# Altium schematic sheet Sheet2.SchDoc(Sheet2)
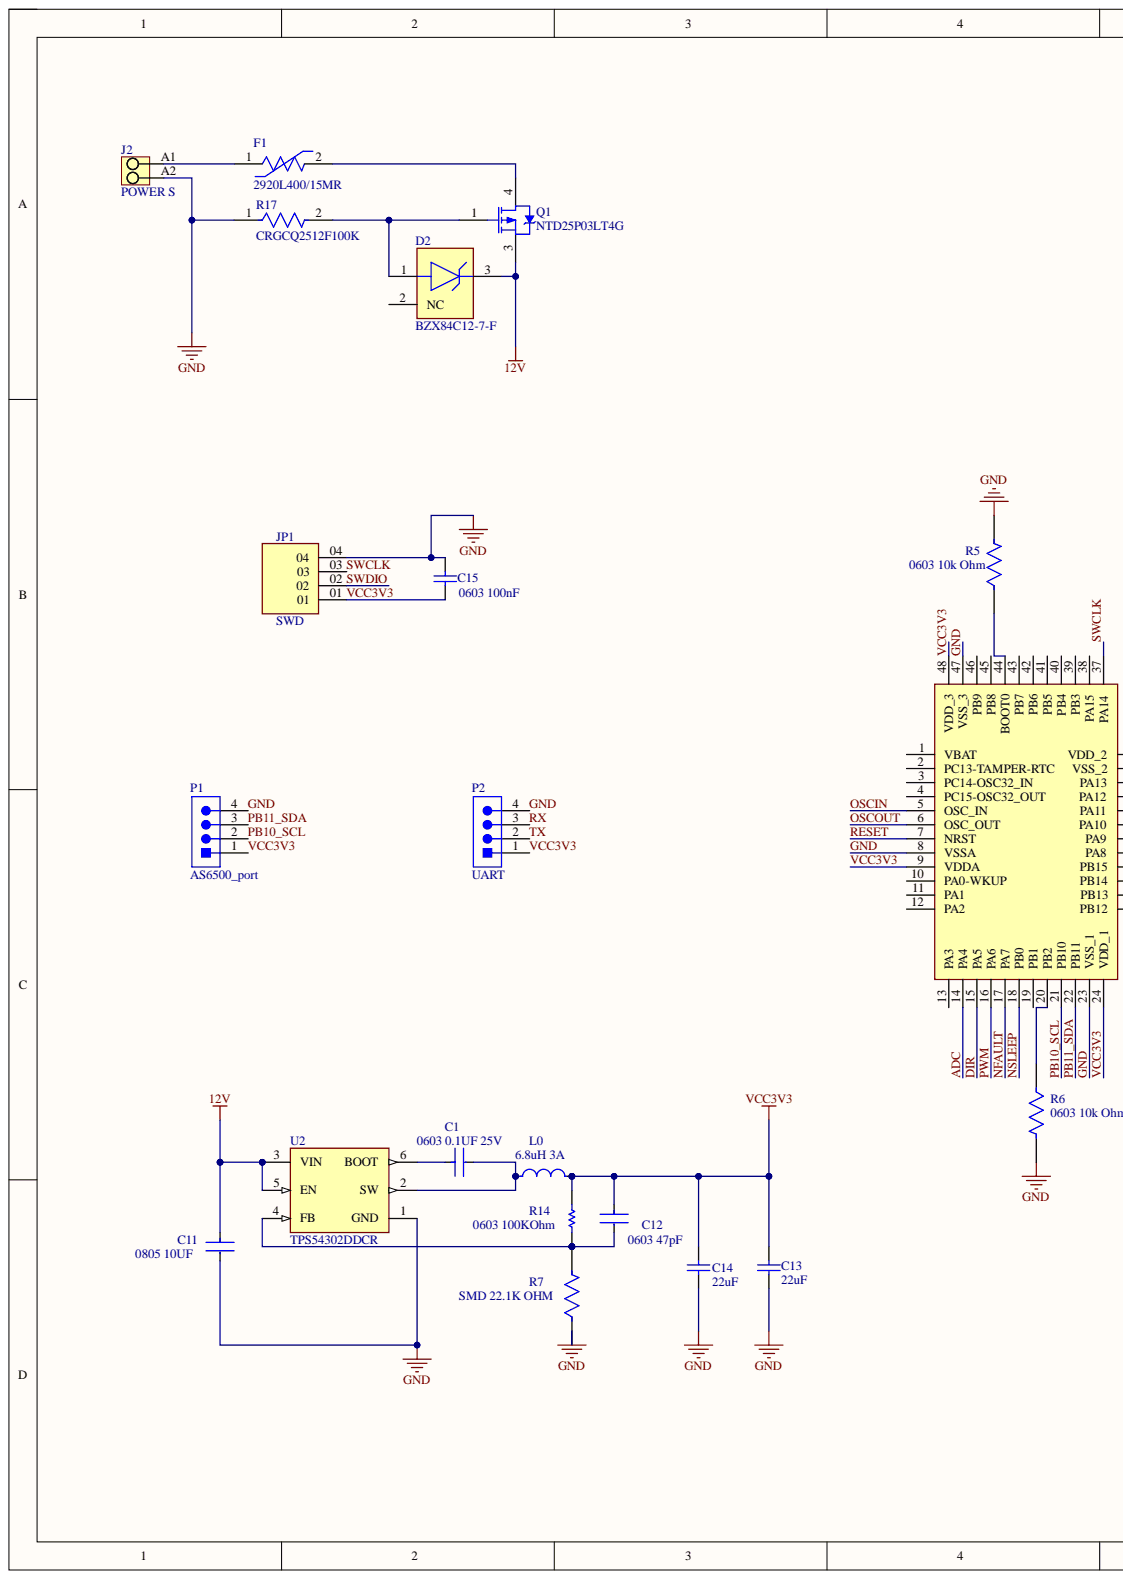
[diagram p0: Sheet2.SchDoc, left]
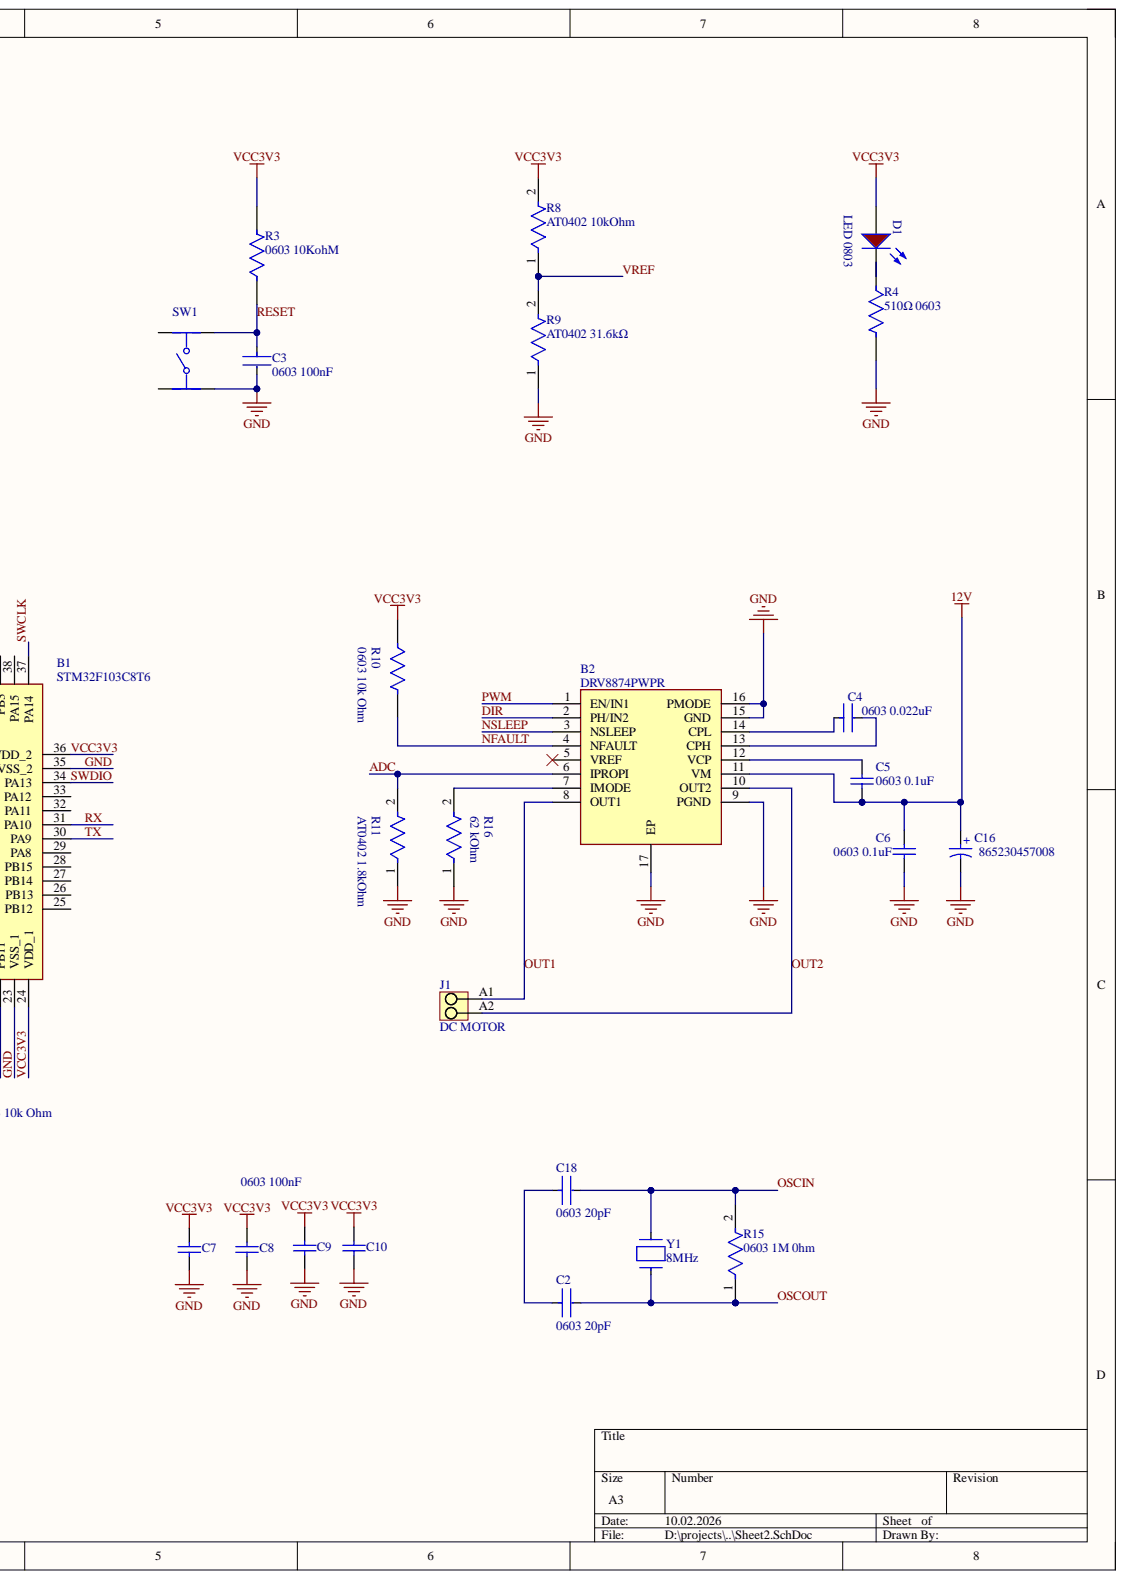
[diagram p1: Sheet2.SchDoc, right]

## Parts
B1  STM32F103C8T6  [QFP50P900X900X160-48N]
B2  DRV8874PWPR  [PWP0016J_L]
C1  0603 0.1UF 25V  [FP-C0603C-CF-MFG]
C2  0603 20pF  value 20 pF  [FP-C0603C-CF-MFG]
C3  0603 100nF  value 100 nF  [FP-CC0603-DA-MFG]
C4  0603 0.022uF  [FP-C0603C-CF-MFG]
C5  0603 0.1uF  [CAPC1608X90X35NL15T15]
C6  0603 0.1uF  [CAPC1608X90X35NL15T15]
C7  0603 100nF  [CAPC1608X92X38NL15T08]
C8  0603 100nF  [CAPC1608X92X38NL15T08]
C9  0603 100nF  [CAPC1608X92X38NL15T08]
C10  0603 100nF  [CAPC1608X92X38NL15T08]
C11  0805 10UF  [FP-C0805C106K3PACTU-MFG]
C12  0603 47pF  value 47 pF  [FP-C0603C-CF-MFG]
C13  22uF  [CAPC2013X152X50ML20T25]
C14  22uF  [CAPC2013X152X50ML20T25]
C15  0603 100nF  [CAPC1608X92X38NL15T08]
C16  865230457008  [WCAP-AS5H_D10H10.5]
C18  0603 20pF  value 20 pF  [FP-C0603C-CF-MFG]
D1  LED 0803  [WL-SMCW_0805_150080xx75000]
D2  BZX84C12-7-F  [FP-SOT23-MFG]
F1  2920L400/15MR  [FP-2920L400-15MR-MFG]
J1  DC MOTOR  [LM_5_08_90_02]
J2  POWER S  [LM_5_08_90_02]
JP1  SWD  [SAMTEC_IPL1-104-01-X-S-K]
L0  6.8uH 3A  [FP-NR6045-MFG]
P1  AS6500_port  [JST-B4B-PH-K-S_V]
P2  UART  [JST-B4B-PH-K-S_V]
Q1  NTD25P03LT4G  [ONSC-DPAK-3-369C-01_V]
R3  0603 10KohM  [FP-CRGCQ0603-IPC_C]
R4  510Ω 0603  [FP-AC0603-MFG]
R5  0603 10k Ohm  [FP-AC0603-IPC_A]
R6  0603 10k Ohm  Case/Package: 0603  [FP-AC0603-IPC_A]
R7  SMD 22.1K OHM  [FP-RT0805-MFG]
R8  AT0402 10kOhm  [FP-AT0402-IPC_A]
R9  AT0402 31.6kΩ  [FP-AT0402-IPC_A]
R10  0603 10k Ohm  Case/Package: 0603  [FP-AC0603-IPC_A]
R11  AT0402 1.8kOhm  [FP-AT0402-IPC_B]
R14  0603 100KOhm  [RESC1608X55X25ML10T15]
R15  0603 1M 0hm  [FP-MCT0603-IPC_A]
R16  62 kOhm  [FP-ESR18-IPC_C]
R17  CRGCQ2512F100K  [FP-CRGCQ2512-IPC_C]
SW1  PTS645SM43SMTR92LFS  [PTS645SM43SMTR92LFS_MFG]  (value not drawn)
U2  TPS54302DDCR  [FP-DDC0006A-MFG]
Y1  8MHz  [FP-ABM3-MFG]

## Nets
12V: B2.11(VM) C5.1 C6.1 C11.1 C16.1 D2.3 Q1.3 U2.3(VIN) U2.5(EN)
ADC: B1.14(PA4) B2.6(IPROPI) R11.2
DIR: B1.15(PA5) B2.2(PH/IN2)
GND: B1.8(VSSA) B1.23(VSS_1) B1.35(VSS_2) B1.47(VSS_3) B2.9(PGND) B2.15(GND) B2.16(PMODE) B2.17(EP) C3.2 C6.2 C7.1 C8.1 C9.1 C10.1 C11.2 C13.1 C14.1 C15.2 C16.2 J2.A2 JP1.04 P1.4 P2.4 R4.2 R5.2 R6.2 R7.1 R9.1 R11.1 R16.1 R17.1 SW1.4 U2.1(GND)
NFAULT: B1.17(PA7) B2.4(NFAULT) R10.2
NSLEEP: B1.18(PB0) B2.3(NSLEEP)
OSCIN: B1.5(OSC_IN) C18.2 R15.2 Y1.2
OSCOUT: B1.6(OSC_OUT) C2.2 R15.1 Y1.1
OUT1: B2.8(OUT1) J1.A1
OUT2: B2.10(OUT2) J1.A2
PB10_SCL: B1.21(PB10) P1.2
PB11_SDA: B1.22(PB11) P1.3
PWM: B1.16(PA6) B2.1(EN/IN1)
RESET: B1.7(NRST) C3.1 R3.1 SW1.2
RX: B1.31(PA10) P2.3
SWCLK: B1.37(PA14) JP1.03
SWDIO: B1.34(PA13) JP1.02
TX: B1.30(PA9) P2.2
VCC3V3: B1.9(VDDA) B1.24(VDD_1) B1.36(VDD_2) B1.48(VDD_3) C7.2 C8.2 C9.2 C10.2 C12.1 C13.2 C14.2 C15.1 D1.2 JP1.01 L0.2 P1.1 P2.1 R3.2 R8.2 R10.1 R14.2
VREF: R8.1 R9.2
NetB1_20: B1.20(PB2) R6.1
NetB1_44: B1.44(BOOT0) R5.1
NetB2_7: B2.7(IMODE) R16.2
NetB2_12: B2.12(VCP) C5.2
NetB2_13: B2.13(CPH) C4.2
NetB2_14: B2.14(CPL) C4.1
NetC1_1: C1.1 U2.6(BOOT)
NetC1_2: C1.2 L0.1 U2.2(SW)
NetC2_1: C2.1 C18.1
NetC12_2: C12.2 R7.2 R14.1 U2.4(FB)
NetD1_1: D1.1 R4.1
NetD2_1: D2.1 Q1.1 R17.2
NetF1_1: F1.1 J2.A1
NetF1_2: F1.2 Q1.4

Not connected: B1 1(VBAT) 2(PC13-TAMPER-RTC) 3(PC14-OSC32_IN) 4(PC15-OSC32_OUT) 10(PA0-WKUP) 11(PA1) 12(PA2) 13(PA3) 19(PB1) 25(PB12) 26(PB13) 27(PB14) 28(PB15) 29(PA8) 32(PA11) 33(PA12) 38(PA15) 39(PB3) 40(PB4) 41(PB5) 42(PB6) 43(PB7) 45(PB8) 46(PB9); B2 5(VREF); D2 2; SW1 1 3

## Library data of the parts
B1 = STM32F103CBT6: Medium-density performance line Arm-based 32-bit MCU with 64 or 128 KB Flash, USB, CAN, 7 timers, 2 ADCs, 9 com. interfaces; Manufacturer_Name: STMicroelectronics; Geometry.Height: 1.6mm; Arrow Part Number: STM32F103CBT6; Arrow Price/Stock: https://www.arrow.com/en/products/stm32f103cbt6/stmicroelectronics.html?utm_currency=USD&region=asia; Component Kind: Standard; Datasheet Link: https://www.st.com/resource/en/datasheet/stm32f103c8.pdf; Library Name: STM32F103CBT6.SchLib; Manufacturer_Part_Number: STM32F103CBT6; Mouser Part Number: 511-STM32F103CBT6; Mouser Price/Stock: https://www.mouser.co.uk/ProductDetail/STMicroelectronics/STM32F103CBT6?qs=WxFF5lh7QM3goh6GV5Ogig%3D%3D
B2 = DRV8874PWPR: H-Bridge Motor Driver With Integrated Current Sense and Regulation; Manufacturer_Name: Texas Instruments; Geometry.Height: 1.2mm; Arrow Part Number: DRV8874PWPR; Arrow Price/Stock: https://www.arrow.com/en/products/drv8874pwpr/texas-instruments.html?utm_currency=USD&region=nac; Component Kind: Standard; Datasheet Link: https://www.ti.com/lit/gpn/DRV8874; Library Name: DRV8874PWPR.SchLib; Manufacturer_Part_Number: DRV8874PWPR; Mouser Part Number: 595-DRV8874PWPR; Mouser Price/Stock: https://www.mouser.co.uk/ProductDetail/Texas-Instruments/DRV8874PWPR?qs=mAH9sUMRCtvh%2FSU15ywmDA%3D%3D
C1 = CMP-2006-03731-2: CAP CER 0.1UF 25V X7R 0603; Manufacturer: KEMET; Manufacturer Part Number: C0603C104K3RACAUTO; Automotive Grade: Grade 1; Capacitance: 0.10 μF; Material: Ceramic; Temperature Coefficient: 30ppm/°C; Tolerance: 0.1; Value: 0.10 μF; Automotive: Yes; Category: Cap; Component Kind: Standard; ComponentLink2Description: Datasheet; ComponentLink2URL: https://api.kemet.com/component-edge/download/datasheet/C0603C100J5GACAUTO.pdf; Device Class L1: Passive Components; Device Class L2: Capacitors; Device Class L3: Ceramic Capacitors; Digikey Description: CAP CER 0.1UF 25V X7R 0603; Height: 0.87mm; Lead Free: Yes; Library Name: Altium Content Vault; Mouser Description: Multilayer Ceramic Capacitors MLCC - SMD/SMT 0.1uF 25volts 10% X7R AUTO; Octopart: https://octopart.com/c0603c104k3racauto-kemet-12595587; Package: C0603C-CF; RoHS: TRUE; Temperature: -55°C to +125°C; Temperature Characteristic: X7R; Temperature Range High: +125°C; Temperature Range Low: -55°C; Voltage Rating: 25 V
C2 C18 = CMP-03020-010331-1: C0603 20 pF X8R 30ppm/°C 1.00% 25 V; Manufacturer: KEMET; Manufacturer Part Number: C0603C200F3HACTU; Automotive Grade: Grade 1; Material: Ceramic; Tolerance: 0.01; Voltage Rating: 25 V; Automotive: Yes; Capacitance: 20 pF; Category: Cap; Component Kind: Standard; ComponentLink2Description: Datasheet; ComponentLink2URL: https://api.kemet.com/component-edge/download/datasheet/C0603C100J5GACAUTO.pdf; Device Class L1: Passive Components; Device Class L2: Capacitors; Device Class L3: Ceramic Capacitors; Height: 0.87mm; Lead Free: Yes; Library Name: Altium Content Vault; Octopart: https://octopart.com/c0603c200f3hactu-kemet-88725274; Package: C0603C-CF; RoHS: TRUE; Temperature: -55°C to +125°C; Temperature Characteristic: X8R; Temperature Coefficient: 30ppm/°C; Temperature Range High: +125°C; Temperature Range Low: -55°C; Value: 20 pF
C3 = CMP-03422-001228-1: CAP CER 0.1UF 10V X7R 0603; Manufacturer: YAGEO; Manufacturer Part Number: CC0603KRX7R6BB104; Material: Ceramic; Temperature Coefficient: 15%; Tolerance: 0.1; Automotive: No; Capacitance: 100 nF; Category: Cap; Component Kind: Standard; ComponentLink2Description: Datasheet; ComponentLink2URL: http://www.yageo.com/documents/recent/UPY-GPHC_X7R_6.3V-to-50V_18.pdf; Device Class L1: Passive Components; Device Class L2: Capacitors; Device Class L3: Ceramic Capacitors; Digikey Description: CAP CER 0.1UF 10V X7R 0603; Footprint Url: http://www.yageo.com/exep/pages/download/literatures/UPY-C_GEN_24.pdf; Height: 0.9mm; Lead Free: Yes; Library Name: Altium Content Vault; Mouser Description: 0603 0.1 uF 10 V ±10 % Tolerance X7R SMT Multilayer Ceramic Capacitor; Octopart: https://octopart.com/cc0603krx7r6bb104-yageo-9291607; Package: CC0603-DA; RoHS: TRUE; Temperature: -55°C to +125°C; Temperature Characteristic: X7R; Temperature Range High: +125°C; Temperature Range Low: -55°C; Value: 100 nF; Voltage: 10 V; Voltage Rating: 10 V
C4 = CMP-03020-003229-1: CAP CER 0.022UF 50V X7R 0603; Manufacturer: KEMET; Manufacturer Part Number: C0603C223M5RAC7867; Automotive Grade: Grade 1; Capacitance: 22,000 pF; Material: Ceramic; Temperature Coefficient: 30ppm/°C; Tolerance: 0.2; Value: 22,000 pF; Automotive: Yes; Category: Cap; Component Kind: Standard; ComponentLink2Description: Datasheet; ComponentLink2URL: https://api.kemet.com/component-edge/download/datasheet/C0603C100J5GACAUTO.pdf; Device Class L1: Passive Components; Device Class L2: Capacitors; Device Class L3: Ceramic Capacitors; Digikey Description: CAP CER 0.022UF 50V X7R 0603; Height: 0.87mm; Lead Free: Yes; Library Name: Altium Content Vault; Octopart: https://octopart.com/c0603c223m5rac7867-kemet-1577116; Package: C0603C-CF; RoHS: TRUE; Temperature: -55°C to +125°C; Temperature Characteristic: X7R; Temperature Range High: +125°C; Temperature Range Low: -55°C; Voltage Rating: 50 V
C5 C6 = CMP-2006-02299-1: Manufacturer: Kemet; Part Number: C0603C104K4RAC7013; Component Kind: Standard; Library Name: Altium Content Vault
C7-C10 C15 = CMP-2006-01211-1: Manufacturer: Vitramon; Part Number: VJ0603Y104KXJAP; Component Kind: Standard; Library Name: Altium Content Vault
C11 = CMP-03020-034663-1: CAP CER 10UF 25V X5R 0805; Dielectric Material: Ceramic; Dissipation Factor: 10%; Capacitance: 10uF; Case Code (Imperial): 0805; Case Code (Metric): 2012; Case/Package: 0805; Component Kind: Standard; Depth: 1.25mm; Dielectric: X5R; Height: 1.25mm; Lead Free: Lead Free; Length: 0.079inch; Library Name: Altium Content Vault; Max Operating Temperature: 85°C; Min Operating Temperature: -55°C; Mount: Surface Mount; Packaging: Cut Tape; REACH SVHC: No SVHC; RoHS Compliant: Yes; Termination: Solder; Thickness: 0.057inch; Tolerance: 10%; Voltage: 25V; Voltage Rating: 25V; Voltage Rating (DC): 25V; Width: 0.049inch
C12 = CMP-03020-013808-1: C0603 47 pF X7R 30ppm/°C 10.00% 100 V; Manufacturer: KEMET; Manufacturer Part Number: C0603C470K1RACTU; Automotive Grade: Grade 1; Material: Ceramic; Tolerance: 0.1; Voltage Rating: 100 V; Automotive: Yes; Capacitance: 47 pF; Category: Cap; Component Kind: Standard; ComponentLink2Description: Datasheet; ComponentLink2URL: https://api.kemet.com/component-edge/download/datasheet/C0603C100J5GACAUTO.pdf; Device Class L1: Passive Components; Device Class L2: Capacitors; Device Class L3: Ceramic Capacitors; Height: 0.87mm; Lead Free: Yes; Library Name: Altium Content Vault; Mouser Description: Cap Ceramic 47pF 100V X7R 10% SMD 0603 125°C Punched Paper T/R; Octopart: https://octopart.com/c0603c470k1ractu-kemet-51453601; Package: C0603C-CF; RoHS: TRUE; Temperature: -55°C to +125°C; Temperature Characteristic: X7R; Temperature Coefficient: 30ppm/°C; Temperature Range High: +125°C; Temperature Range Low: -55°C; Value: 47 pF
C13 C14 = CMP-2007-04522-1: Manufacturer: AVX Interconnect / Elco; Part Number: 0805ZD226MAT2A; Component Kind: Standard; Library Name: Altium Content Vault
C16 = CMP-03913-53914687-2: WCAP-AS5H Aluminum Electrolytic Capacitors; Capacitance: 330µF; Insulation Resistance: 303.03kΩ; Leakage Current: 82.5µA; Life (Hours): 5000hours; Polarity: Polar; Ripple Current: 375mA; Tolerance: 20%; Voltage Rating: 25V; Case/Package: Radial; Component Kind: Standard; Diameter: 10mm; Height: 10.5mm; Height - Seated (Max): 10.4902mm; Length: 10.5mm; Library Name: Altium Content Vault; Max Operating Temperature: 105°C; Min Operating Temperature: -40°C; Mount: Surface Mount; REACH SVHC: Unknown; Ripple Current (AC): 375mA; RoHS: Compliant; Voltage Rating (DC): 25V; Width: 10.3mm
D1 = CMP-1426-00010-5: WL-SMCW SMD chip LED top view monocolor waterclear; Manufacturer Lifecycle Status: ACTIVE; Manufacturer Package Identifier: 0805 (2012 metric); Color: Red; Dominant Wavelength: 630nm; Forward Current: 100mA; Forward Voltage: 1.9V; Lens Style: Clear, Rectangular; Luminous Intensity: 60mcd; Max Junction Temperature (Tj): 95°C; Max Reverse Voltage (DC): 5V; Peak Wavelength: 645nm; Power Dissipation: 72mW; Test Current: 20mA; Viewing Angle: 140°; YEOL: 1 = Estimated lifetime > 10 years; Case Code (Imperial): 0805; Case Code (Metric): 2012; Case/Package: 0805; Component Kind: Standard; Height: 700µm; Length: 2mm; Library Name: Altium Content Vault; Lifecycle Status: Production; Max Operating Temperature: 85°C; Min Operating Temperature: -40°C; Mount: Surface Mount; Packaging: Tape and Reel; REACH SVHC: Unknown; Reverse Voltage: 5V; RoHS: Compliant; Wavelength: 630nm; Width: 1.25mm
D2 = CMP-12792-000017-1: DIODE ZENER 12V 300MW SOT23-3; Manufacturer: Diodes Inc.; Max Junction Temp: +150°C; Max Reverse Leakage Current: 0.1uA; Max Zener Voltage: 12.7V; Reverse Voltage: 8V; Standoff Height: 0.013mm; Zener Impedance: 25Ω; Automotive: No; Category: Diode; Component Kind: Standard; ComponentLink2Description: Datasheet; ComponentLink2URL: https://www.diodes.com/assets/Datasheets/ds18001.pdf; Device Class L1: Discrete Semiconductors; Device Class L2: Diodes; Device Class L3: Zener Diodes; Digikey Description: DIODE ZENER 12V 300MW SOT23-3; Footprint Url: https://www.diodes.com/assets/Package-Files/SOT23.pdf; Height: 1.1mm; Ipc Land Pattern Name: SOT92P240X102-3; Lead Free: Yes; Library Name: Altium Content Vault; Manufacturer Part Number: BZX84C12-7-F; Mouser Description: Zener Diodes 12V 350mW; Nom Zener Voltage: 12V; Octopart: https://octopart.com/bzx84c12-7-f-diodes+inc.-327696; Package: SOT23; Power Dissipation: 300mW; RoHS: TRUE; Temperature: -65°C to +150°C; Temperature Range High: +150°C; Temperature Range Low: -65°C
F1 = CMP-08606-000010-2: PTC RESET FUSE 15V 4A 2920; Approvals: TUV; Max Current Rating: 40A; Time to Trip: 4s; Trip Current: 8A; Case Code (Imperial): 2920; Case Code (Metric): 7351; Component Kind: Standard; Hold Current: 4A; Library Name: Altium Content Vault; Max Operating Temperature: 85°C; Min Operating Temperature: -40°C; Mount: Surface Mount; Packaging: Tape and Reel
J1 J2 = CMP-1559-01766-1: OMNIMATE Signal - series LM; Manufacturer: Weidmueller; PartNumber: 1716080000; Contact_material: Cu-Leg; Contact_surface: tinned; Insulating_material: Wemid (PA); Mounting_onto_the_PCB: Solder connection; No_of_poles: 2; Pitch_inch: 0,2 inch; Pitch_mm: 5,08 mm; Rated_current_use_group_B_UL_1059: 15 A; Rated_voltage_for_surge_voltage_class_pollution_degree_II_2: 630 V; Rated_voltage_use_group_B_UL_1059: 300 V; Short_text_for_material: LM 5.08/02/90 3.5SN OR BX; Side_termination_characteristic: closed side; Solder_pin_length_mm: 3,5 mm; Solid_max_H05_07_V_U: 2,5 mm²; Solid_min_H05_07_V_U: 0,2 mm²; UL_94_flammability_rating: V-0; Wire_cross_section_AWG_max_UL_1059: AWG 14; Wire_cross_section_AWG_min_UL_1059: AWG 24; Colour: Orange; Component Kind: Standard; ComponentLink1Description: Manufacturer URL; ComponentLink1URL: http://www.weidmueller.com/; ComponentLink2Description: URL Link1; ComponentLink2URL: http://catalog.weidmueller.com/catalog/Start.do?localeId=en&ObjectID=1716080000; Library Name: Altium Content Vault; PackageReference: LM_5_08_90_02; Packaging: Box; Qty: 500 PCS; Revision: V1
JP1 = IPL1-104-01-X-S-K: MF: Samtec; Availability: Unavailable; Component Kind: Standard; Library Name: IPL1-104-01-X-S-K.IntLib; MP: IPL1-104-01-X-S-K; Package: None; Price: None
L0 = CMP-14484-000868-1: Shielded Wirewound Inductor 6.8uH 20% 3.3A 46.8mΩ SMD; Component Kind: Standard; Library Name: Altium Content Vault; RoHS Compliant: Yes
P1 P2 = CMP-2000-05165-1: Male Header, Pitch 2 mm, 1 x 4 Position, Height 6 mm, Tail Length 3.4 mm, -25 to 85 degC, RoHS, Bulk; Manufacturer: JST; No of Positions: 4; Pitch: 2mm; Style/Orientation: StraightHeader/Plug; Component Kind: Standard; Datasheet URL: http://datasheet.octopart.com/B4B-PH-K-S%28LF%29%28SN%29-JST-datasheet-10558886.pdf; Datasheet Version: Apr-09; Library Name: Altium Content Vault; Manufacturer URL: http://www.jst.com/home2.html; Termination: Crimp; Type: ShroudedHeader
Q1 = CMP-1058-00409-1: Power MOSFET, -25 A, -30 V, Logic Level P-Channel, 3-Pin DPAK, Pb-Free, Tape and Reel; Manufacturer: On Semiconductor; Channel Polarity: P Channel; Ciss Typ (pF): 900; Configuration: Single; Coss Typ (pF): 290; Crss Typ (pF): 105; ID Max (A): 25; PD Max (W): 75; Qrr Typ (nC): 35; V(BR)DSS Min (V): 30; VGS Max (V): 15; VGS(th) Max (V): 2; Component Kind: Standard; ComponentLink1Description: Manufacturer URL; ComponentLink1URL: http://www.onsemi.com/; ComponentLink2Description: Datasheet; ComponentLink2URL: http://www.onsemi.cn/pub_link/Collateral/NTD25P03L-D.PDF; ComponentLink3Description: Package Specification; ComponentLink3URL: http://www.onsemi.cn/pub_link/Collateral/369C.PDF; DatasheetVersion: Rev. 4; Library Name: Altium Content Vault; Mounting Technology: Surface Mount; Package Type: DPAK-3; PackageDescription: 3-Pin Flange Mount, Body 6.54 x 6.095 mm, Pitch 2.29 mm; PackageReference: DPAK-3-369C-01; PackageVersion: Rev. E, 02/2014; Packing: Tape and Reel; PartNumber: NTD25P03LT4G; RoHS: Pb-Free
R3 = CMP-03211-009884-1: CRGCQ 0603 10K 5%; Manufacturer: TE Connectivity; Manufacturer Part Number: CRGCQ0603J10K; Power Rating: 0.1W; Resistance: 10KΩ; Temperature Coefficient: ±100ppm/°C; Tolerance: 0.05; Value: 10KΩ; Voltage Rating: 75V; Ambient Temperature Range High: +70°C; Category: Res; Component Kind: Standard; ComponentLink2Description: Datasheet; ComponentLink2URL: https://www.te.com/commerce/DocumentDelivery/DDEController?Action=showdoc&DocId=Data+Sheet%7F1773204-3%7F1%7Fpdf%7FEnglish%7FENG_DS_1773204-3_1.pdf%7F4-2176339-923; Composition: Thick Film; Device Class L1: Passive Components; Device Class L2: Resistors; Device Class L3: Chip SMD Resistors; Digikey Description: CRGCQ 0603 10K 5%; Height: 0.55mm; Ipc Land Pattern Name: RESC16080X45; Lead Free: Yes; Library Name: Altium Content Vault; Mouser Description: Res Thick Film 0603 10K Ohm 5% 0.1W(1/10W) ±100ppm/C Pad SMD Automotive T/R; Octopart: https://octopart.com/crgcq0603j10k-te+connectivity-91018097; Package: CRGCQ0603; RoHS: TRUE; Series: CRGCQ; Temperature: -55°C to +155°C; Temperature Range High: +155°C; Temperature Range Low: -55°C
R4 = CMP-03412-039135-1: RES 510Ω 5.00% 0.1W 0603; Component Kind: Standard; Library Name: Altium Content Vault
R5 R6 R10 = CMP-03412-042500-1: RES 10kΩ 5.00% 0.1W 0603; Resistance: 10kR; Tolerance: 5%; Component Kind: Standard; Lead Free: Lead Free; Library Name: Altium Content Vault; RoHS Compliant: Yes
  R5: Case/Package: 0603
R7 = CMP-03412-036974-1: RES SMD 22.1K OHM 1% 1/8W 0805; Manufacturer: Yageo; Manufacturer Part Number: RT0805FRD0722K1L; Power Rating: 0.125W; Resistance: 22.1KΩ; Temperature Coefficient: 25 ppm/°C; Tolerance: 0.0001; Value: 22.1KΩ; Voltage Rating: 150V; Automotive: No; Category: Res; Component Kind: Standard; ComponentLink2Description: Datasheet; ComponentLink2URL: http://www.yageo.com/documents/recent/PYu-RT_1-to-0.01_RoHS_L_9.pdf; Composition: Thin Film; Device Class L1: Passive Components; Device Class L2: Resistors; Device Class L3: Chip SMD Resistors; Digikey Description: RES SMD 22.1K OHM 1% 1/8W 0805; Footprint Url: http://www.yageo.com/exep/pages/download/literatures/PYu-R_Mount_10.pdf; Height: 0.6mm; Ipc Land Pattern Name: RESC200125X50; Lead Free: Yes; Library Name: Altium Content Vault; Octopart: https://octopart.com/rt0805frd0722k1l-yageo-71399869; Package: RT0805; RoHS: TRUE; Series: RT; Temperature: -55°C to +155°C; Temperature Range High: +155°C; Temperature Range Low: -55°C
R8 = CMP-03412-049037-1: Thin Film Chip Resistors Automotive Grade 10kΩ 0.1% 50ppm/°C 0402; Max Power Dissipation: 63mW; Resistance: 10kR; Case/Package: 0402; Component Kind: Standard; Features: Moisture Resistant; Height: 0.014inch; Length: 0.039inch; Library Name: Altium Content Vault; Max Operating Temperature: 155°C; Min Operating Temperature: -55°C; Number of Terminations: 2; Packaging: Tape and Reel; Resistor Type: Thin Film; RoHS Compliant: Yes; Series: AT; Temperature Coefficient: 50ppm/°C; Tolerance: 0.1%; Width: 0.02inch
R9 = CMP-03412-044380-1: Thin Film Chip Resistors Automotive Grade 31.6kΩ 0.1% 25ppm/°C 0402; Max Power Dissipation: 63mW; Resistance: 31.6kR; Case/Package: 0402; Component Kind: Standard; Features: Moisture Resistant; Height: 0.014inch; Length: 0.039inch; Library Name: Altium Content Vault; Max Operating Temperature: 155°C; Min Operating Temperature: -55°C; Number of Terminations: 2; Packaging: Tape and Reel; Resistor Type: Thin Film; RoHS Compliant: Yes; Series: AT; Temperature Coefficient: 25ppm/°C; Tolerance: 0.1%; Width: 0.02inch
R11 = CMP-03412-048639-1: Thin Film Chip Resistors Automotive Grade 1.8kΩ 0.5% 50ppm/°C 0402; Max Power Dissipation: 63mW; Resistance: 1.8kR; Case/Package: 0402; Component Kind: Standard; Features: Moisture Resistant; Height: 0.014inch; Length: 0.039inch; Library Name: Altium Content Vault; Max Operating Temperature: 155°C; Min Operating Temperature: -55°C; Number of Terminations: 2; Packaging: Tape and Reel; Resistor Type: Thin Film; RoHS Compliant: Yes; Series: AT; Temperature Coefficient: 50ppm/°C; Tolerance: 0.5%; Width: 0.02inch
R14 = CMP-2000-04193-1: Manufacturer: Yageo; Part Number: RC0603FR-13100KL; Component Kind: Standard; Library Name: Altium Content Vault
R15 = CMP-02407-001048-1: RES SMD 100 OHM 1% 1/8W 0603; Component Kind: Standard; Library Name: Altium Content Vault
R16 = CMP-08839-029145-1: 62kΩ ±5% 0.5W 1206 Anti-Surge Chip Resistor AEC-Q200; Max Power Dissipation: 333mW; Resistance: 62kR; Temperature Coefficient: 200ppm/°C; Case Code (Imperial): 1206; Case Code (Metric): 3216; Case/Package: 1206; Component Kind: Standard; Features: Automotive AEC-Q200; Height: 0.026inch; Length: 0.126inch; Library Name: Altium Content Vault; Max Operating Temperature: 155°C; Min Operating Temperature: -55°C; Number of Terminations: 2; Package Quantity: 1; Packaging: Tape and Reel; Resistor Type: Thick Film; RoHS Compliant: Yes; Series: ESR; Tolerance: 5%; Width: 0.063inch
R17 = CMP-03211-002138-1: CRGCQ2512 100KΩ 1W ±100ppm/°C 1%200V; Manufacturer: TE Connectivity; Automotive Grade: Grade 0; Tolerance: 0.01; Voltage Rating: 200V; Automotive: Yes; Category: Res; Component Kind: Standard; ComponentLink2Description: Datasheet; ComponentLink2URL: https://www.te.com/commerce/DocumentDelivery/DDEController?Action=showdoc&DocId=Data+Sheet%7F1773204-3%7F1%7Fpdf%7FEnglish%7FENG_DS_1773204-3_1.pdf%7F4-2176349-1150; Composition: Thick Film; Device Class L1: Passive Components; Device Class L2: Resistors; Device Class L3: Chip SMD Resistors; Height: 0.65mm; Ipc Land Pattern Name: RESC635320X55; Lead Free: Yes; Library Name: Altium Content Vault; Manufacturer Part Number: CRGCQ2512F100K; Octopart: https://octopart.com/crgcq2512f100k-te+connectivity-91018554; Package: CRGCQ2512; Power Rating: 1W; Resistance: 100KΩ; RoHS: TRUE; Series: CRGCQ; Temperature: -55°C to +155°C; Temperature Coefficient: ±100ppm/°C; Temperature Range High: +155°C; Temperature Range Low: -55°C; Value: 100KΩ
SW1 = CMP-2000-05160-3: Switch Tactile N.O SPST Round Button Gull Wing 0.05A 12VDC 100000Cycle 1.57N SMD T/R; Actuator Length: 850µm; Actuator Material: Nylon, Polyamide; Contact Current Rating: 50mA; Contact Resistance: 100mΩ; Electrical Life: 100kcycles; Illumination Type: Illuminated, Non-Illuminated; Insulation Resistance: 100GΩ; Max Voltage Rating (DC): 12V; Number of Positions: 1; Switch Function: Off-Mom; Case/Package: SMD/SMT; China RoHS: Compliant; Color: Black; Component Kind: Standard; ComponentLink1Description: Manufacturer's Website; ComponentLink1URL: http://www.ck-components.com/; ComponentLink2Description: Datasheet URL; ComponentLink2URL: https://www.ckswitches.com/media/1471/pts645.pdf; Contact Material: Silver; Contact Plating: Silver; Current Rating: 50mA; Depth: 9mm; Height: 3.45mm; HelpURL: https://www.ckswitches.com/media/1471/pts645.pdf; Lead Free: Lead Free; Length: 6mm; Library Name: Altium Content Vault; Life (Cycles): 100kcycles; Lifecycle Status: Production; Manufacturer Package Identifier: PTS645SM43SMTR92LFS; Max Current Rating: 50mA; Max Operating Temperature: 60°C; Mechanical Life: 100kcycles; Min Operating Temperature: -20°C; Mount: Surface Mount; Operating Force: 1.57N; Orientation: Straight; Packaging: Cut Tape (CT); REACH SVHC: Yes; RoHS: Compliant; Termination: Solder; Throw Configuration: SPST; Voltage Rating (DC): 12V
U2 = CMP-04918-000032-1: IC REG BUCK ADJ 3A TSOT23-6; Manufacturer: Texas Instruments; Max Duty Cycle: 100%; Max Junction Temp: +125°C; Max Supply Voltage: 28V; Min Supply Voltage: 4.5V; Nominal Supply Current: 45uA; Number Of Outputs: 1; Output Type: AdjustableProgrammable; Output Voltage: 0.6-26V; Standoff Height: 0mm; Switching Frequency: 400kHz; Automotive: No; Category: IC; Component Kind: Standard; ComponentLink2Description: Datasheet; ComponentLink2URL: http://www.ti.com/lit/ds/symlink/tps54302.pdf; Device Class L1: Integrated Circuits (ICs); Device Class L2: Power Management ICs; Device Class L3: Voltage Regulators - Switching; Digikey Description: IC REG BUCK ADJ 3A TSOT23-6; Height: 1.1mm; Ipc Land Pattern Name: SOT95P280X110-6; Lead Free: Yes; Library Name: Altium Content Vault; Manufacturer Part Number: TPS54302DDCR; Octopart: https://octopart.com/tps54302ddcr-texas+instruments-74209025; Output Current: 3A; Package: DDC0006A; RoHS: TRUE; Switching Topology: Buck; Temperature: -40°C to +125°C; Temperature Range High: +125°C; Temperature Range Low: -40°C
Y1 = CMP-2000-05033-2: Crystal 8MHz ±20ppm 18pF SMD-2 5mm x 3.2mm; ESR (Equivalent Series Resistance): 140R; Frequency Stability: 30ppm; Series Resistance: 500R; Case/Package: CSMD; Component Kind: Standard; Depth: 3.2mm; Frequency: 8MHz; Frequency Tolerance: 20ppm; Height: 0.051inch; Lead Free: Lead Free; Length: 5mm; Library Name: Altium Content Vault; Load Capacitance: 18pF; Max Operating Temperature: 85°C; Min Operating Temperature: -40°C; Mount: Surface Mount; Number of Pins: 2; Package Quantity: 1000; Packaging: Tape and Reel; Radiation Hardening: No; Resistor Type: Ceramic; RoHS Compliant: Yes; Termination: SMD/SMT; Tolerance: 20ppm; Width: 3.2mm
